# Altium schematic sheet STAC_Test_Board_v1.SchDoc(STAC_Test_Board_v1)
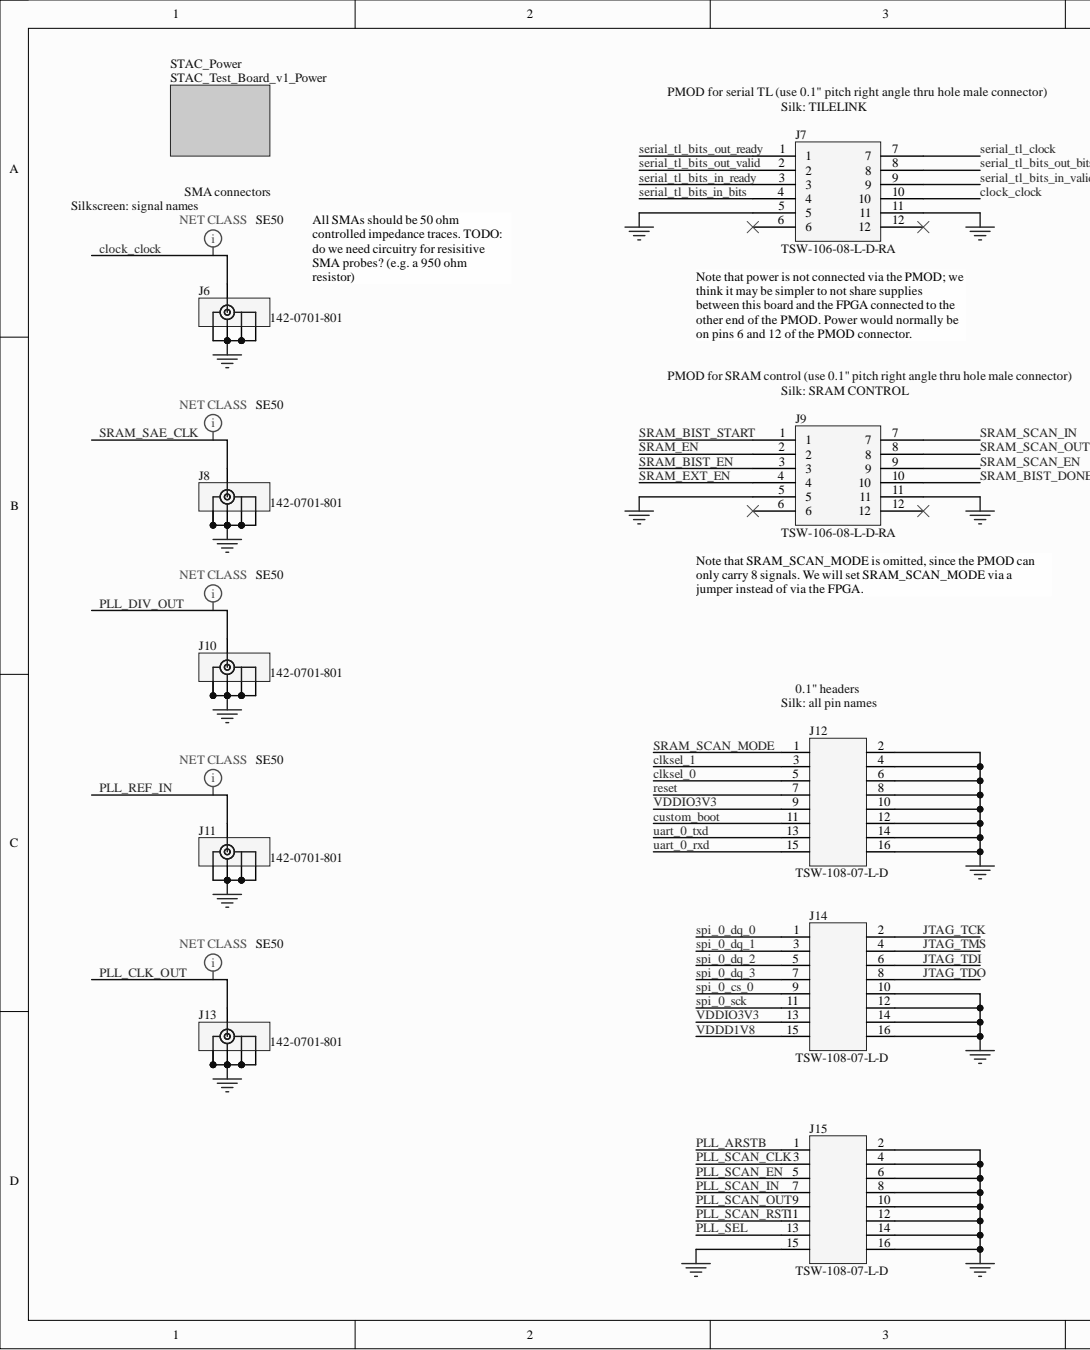
[diagram p0: STAC_Test_Board_v1.SchDoc, left]
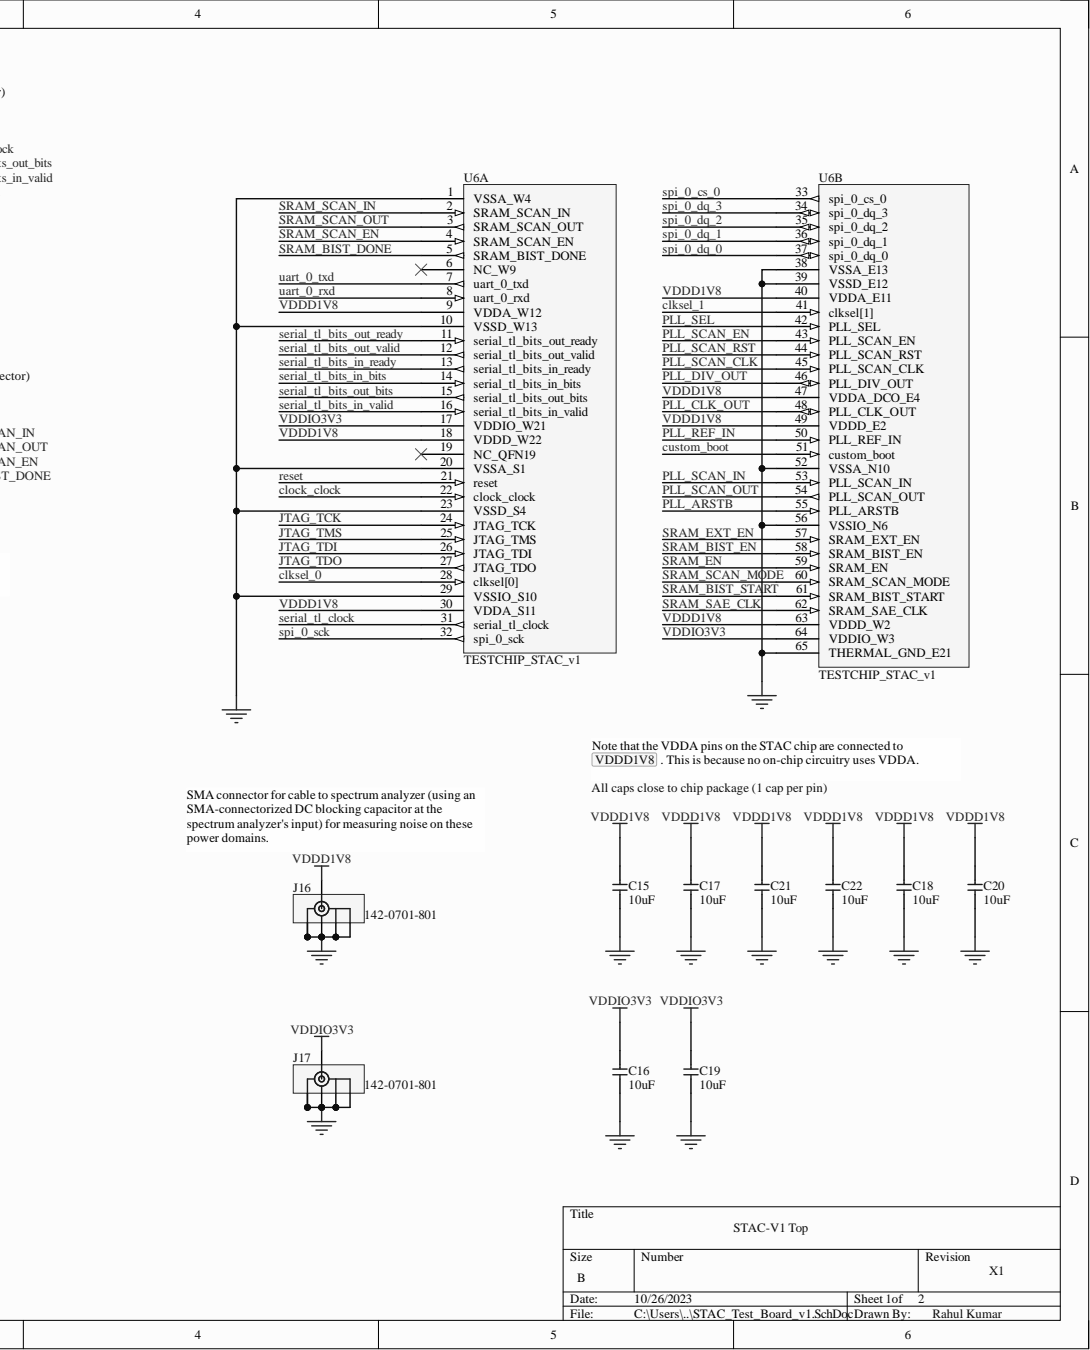
[diagram p1: STAC_Test_Board_v1.SchDoc, right]

## Parts
C15  10uF  [0603]
C16  10uF  [0603]
C17  10uF  [0603]
C18  10uF  [0603]
C19  10uF  [0603]
C20  10uF  [0603]
C21  10uF  [0603]
C22  10uF  [0603]
J6  142-0701-801  [CON-001-9.5X7.9/SMA-EDGE]
J7  TSW-106-08-L-D-RA  [CON-012-100-600X200/2X6-POST-RA_alt]
J8  142-0701-801  [CON-001-9.5X7.9/SMA-EDGE]
J9  TSW-106-08-L-D-RA  [CON-012-100-600X200/2X6-POST-RA_alt]
J10  142-0701-801  [CON-001-9.5X7.9/SMA-EDGE]
J11  142-0701-801  [CON-001-9.5X7.9/SMA-EDGE]
J12  TSW-108-07-L-D  [CON-016-100-800X200/2X8-POST]
J13  142-0701-801  [CON-001-9.5X7.9/SMA-EDGE]
J14  TSW-108-07-L-D  [CON-016-100-800X200/2X8-POST]
J15  TSW-108-07-L-D  [CON-016-100-800X200/2X8-POST]
J16  142-0701-801  [CON-001-9.5X7.9/SMA-EDGE]
J17  142-0701-801  [CON-001-9.5X7.9/SMA-EDGE]
U6  TESTCHIP_STAC_v1  [QFN-64-.5-9.0X9.0/THERM-7.6]  (parts A,B)

## Nets
GND: C15.2 C16.2 C17.2 C18.2 C19.2 C20.2 C21.2 C22.2 J6.2 J6.3 J6.4 J6.5 J7.5 J7.11 J8.2 J8.3 J8.4 J8.5 J9.5 J9.11 J10.2 J10.3 J10.4 J10.5 J11.2 J11.3 J11.4 J11.5 J12.2 J12.4 J12.6 J12.8 J12.10 J12.12 J12.14 J12.16 J13.2 J13.3 J13.4 J13.5 J14.10 J14.12 J14.14 J14.16 J15.2 J15.4 J15.6 J15.8 J15.10 J15.12 J15.14 J15.15 J15.16 J16.2 J16.3 J16.4 J16.5 J17.2 J17.3 J17.4 J17.5 U6.1(VSSA_W4) U6.10(VSSD_W13) U6.20(VSSA_S1) U6.23(VSSD_S4) U6.29(VSSIO_S10) U6.38(VSSA_E13) U6.39(VSSD_E12) U6.52(VSSA_N10) U6.56(VSSIO_N6) U6.65(THERMAL_GND_E21)
clksel_0: J12.5 U6.28(clksel[0])
clksel_1: J12.3 U6.41(clksel[1])
clock_clock: J6.1 J7.10 U6.22(clock_clock)
custom_boot: J12.11 U6.51(custom_boot)
JTAG_TCK: J14.2 U6.24(JTAG_TCK)
JTAG_TDI: J14.6 U6.26(JTAG_TDI)
JTAG_TDO: J14.8 U6.27(JTAG_TDO)
JTAG_TMS: J14.4 U6.25(JTAG_TMS)
PLL_ARSTB: J15.1 U6.55(PLL_ARSTB)
PLL_CLK_OUT: J13.1 U6.48(PLL_CLK_OUT)
PLL_DIV_OUT: J10.1 U6.46(PLL_DIV_OUT)
PLL_REF_IN: J11.1 U6.50(PLL_REF_IN)
PLL_SCAN_CLK: J15.3 U6.45(PLL_SCAN_CLK)
PLL_SCAN_EN: J15.5 U6.43(PLL_SCAN_EN)
PLL_SCAN_IN: J15.7 U6.53(PLL_SCAN_IN)
PLL_SCAN_OUT: J15.9 U6.54(PLL_SCAN_OUT)
PLL_SCAN_RST: J15.11 U6.44(PLL_SCAN_RST)
PLL_SEL: J15.13 U6.42(PLL_SEL)
reset: J12.7 U6.21(reset)
serial_tl_bits_in_bits: J7.4 U6.14(serial_tl_bits_in_bits)
serial_tl_bits_in_ready: J7.3 U6.13(serial_tl_bits_in_ready)
serial_tl_bits_in_valid: J7.9 U6.16(serial_tl_bits_in_valid)
serial_tl_bits_out_bits: J7.8 U6.15(serial_tl_bits_out_bits)
serial_tl_bits_out_ready: J7.1 U6.11(serial_tl_bits_out_ready)
serial_tl_bits_out_valid: J7.2 U6.12(serial_tl_bits_out_valid)
serial_tl_clock: J7.7 U6.31(serial_tl_clock)
spi_0_cs_0: J14.9 U6.33(spi_0_cs_0)
spi_0_dq_0: J14.1 U6.37(spi_0_dq_0)
spi_0_dq_1: J14.3 U6.36(spi_0_dq_1)
spi_0_dq_2: J14.5 U6.35(spi_0_dq_2)
spi_0_dq_3: J14.7 U6.34(spi_0_dq_3)
spi_0_sck: J14.11 U6.32(spi_0_sck)
SRAM_BIST_DONE: J9.10 U6.5(SRAM_BIST_DONE)
SRAM_BIST_EN: J9.3 U6.58(SRAM_BIST_EN)
SRAM_BIST_START: J9.1 U6.61(SRAM_BIST_START)
SRAM_EN: J9.2 U6.59(SRAM_EN)
SRAM_EXT_EN: J9.4 U6.57(SRAM_EXT_EN)
SRAM_SAE_CLK: J8.1 U6.62(SRAM_SAE_CLK)
SRAM_SCAN_EN: J9.9 U6.4(SRAM_SCAN_EN)
SRAM_SCAN_IN: J9.7 U6.2(SRAM_SCAN_IN)
SRAM_SCAN_MODE: J12.1 U6.60(SRAM_SCAN_MODE)
SRAM_SCAN_OUT: J9.8 U6.3(SRAM_SCAN_OUT)
uart_0_rxd: J12.15 U6.8(uart_0_rxd)
uart_0_txd: J12.13 U6.7(uart_0_txd)
VDDD1V8: C15.1 C17.1 C18.1 C20.1 C21.1 C22.1 J14.15 J16.1 U6.9(VDDA_W12) U6.18(VDDD_W22) U6.30(VDDA_S11) U6.40(VDDA_E11) U6.47(VDDA_DCO_E4) U6.49(VDDD_E2) U6.63(VDDD_W2)
VDDIO3V3: C16.1 C19.1 J12.9 J14.13 J17.1 U6.17(VDDIO_W21) U6.64(VDDIO_W3)
NetJ7_6: J7.6
NetJ7_12: J7.12
NetJ9_6: J9.6
NetJ9_12: J9.12
NetU6_6: U6.6(NC_W9)
NetU6_19: U6.19(NC_QFN19)

## Library data of the parts
C15-C22 = DISCRETE_C: Capacitor generic; Component Kind: Standard; Library Name: BWRC.SchLib
J6 J8 J10 J11 J13 J16 J17 = CON_RF_SMA: Con RF SMA; Component Kind: Standard; Library Name: BWRC.SchLib
J7 J9 = CON_012pin_2x6: Con 12 pins 2x6; Component Kind: Standard; Library Name: BWRC.SchLib
J12 J14 J15 = CON_016pin_2x8: Con 16 pins 2x8; Component Kind: Standard; Library Name: BWRC.SchLib
U6 = TESTCHIP_STAC_v1: TESTCHIP STAC v1; Component Kind: Standard; Library Name: BWRC.SchLib
